# Altium schematic sheet 03_Relay.SchDoc(03_Relay)
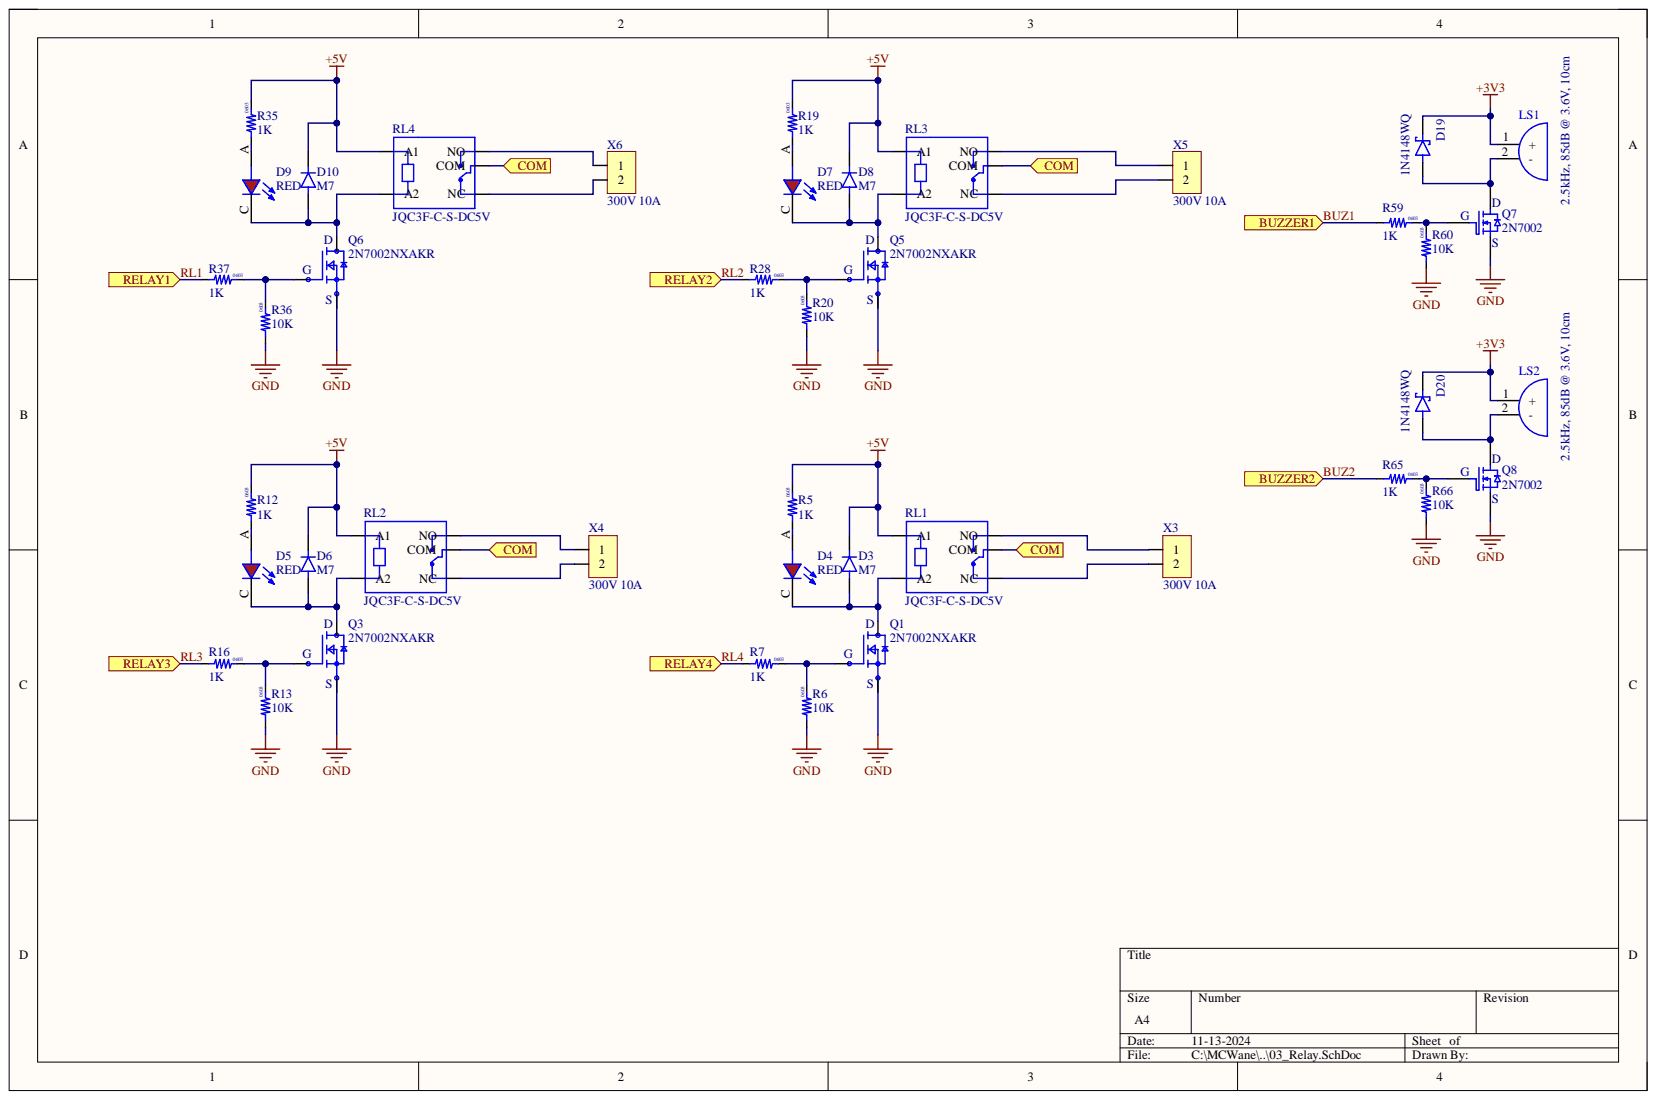
[diagram p0: 03_Relay.SchDoc]

## Parts
D3  M7  [Diode_SMA_DO-214AC]
D4  RED  [LED_0603]
D5  RED  [LED_0603]
D6  M7  [Diode_SMA_DO-214AC]
D7  RED  [LED_0603]
D8  M7  [Diode_SMA_DO-214AC]
D9  RED  [LED_0603]
D10  M7  [Diode_SMA_DO-214AC]
D19  1N4148WQ  [SOD123]
D20  1N4148WQ  [SOD123]
LS1  2.5kHz, 85dB @ 3.6V, 10cm  [SMT-0825-T-HT-R]
LS2  2.5kHz, 85dB @ 3.6V, 10cm  [SMT-0825-T-HT-R]
Q1  2N7002NXAKR  [SOT23]
Q3  2N7002NXAKR  [SOT23]
Q5  2N7002NXAKR  [SOT23]
Q6  2N7002NXAKR  [SOT23]
Q7  2N7002  [SOT23]
Q8  2N7002  [SOT23]
R5  1K  Package: 0603  [RES_0603]
R6  10K  Package: 0603  [RES_0603]
R7  1K  Package: 0603  [RES_0603]
R12  1K  Package: 0603  [RES_0603]
R13  10K  Package: 0603  [RES_0603]
R16  1K  Package: 0603  [RES_0603]
R19  1K  Package: 0603  [RES_0603]
R20  10K  Package: 0603  [RES_0603]
R28  1K  Package: 0603  [RES_0603]
R35  1K  Package: 0603  [RES_0603]
R36  10K  Package: 0603  [RES_0603]
R37  1K  Package: 0603  [RES_0603]
R59  1K  Package: 0603  [RES_0603]
R60  10K  Package: 0603  [RES_0603]
R65  1K  Package: 0603  [RES_0603]
R66  10K  Package: 0603  [RES_0603]
RL1  JQC3F-C-S-DC5V  [RELAY_SUGAR_CUBE]
RL2  JQC3F-C-S-DC5V  [RELAY_SUGAR_CUBE]
RL3  JQC3F-C-S-DC5V  [RELAY_SUGAR_CUBE]
RL4  JQC3F-C-S-DC5V  [RELAY_SUGAR_CUBE]
X3  300V 10A  [Terminal_2_Pin_5.08mm_Screw]
X4  300V 10A  [Terminal_2_Pin_5.08mm_Screw]
X5  300V 10A  [Terminal_2_Pin_5.08mm_Screw]
X6  300V 10A  [Terminal_2_Pin_5.08mm_Screw]

## Ports
BUZZER1, BUZZER2, COM, RELAY1, RELAY2, RELAY3, RELAY4

## Nets
+3V3: D19.C D20.C LS1.1 LS2.1
+5V: D3.C D6.C D8.C D10.C R5.2 R12.2 R19.2 R35.2 RL1.A1 RL2.A1 RL3.A1 RL4.A1
GND: Q1.2 Q3.2 Q5.2 Q6.2 Q7.2 Q8.2 R6.1 R13.1 R20.1 R36.1 R60.1 R66.1
BUZ1: R59.1 ; port BUZZER1
BUZ2: R65.1 ; port BUZZER2
COM: RL1.COM RL2.COM RL3.COM RL4.COM ; port COM
RL1: R37.1 ; port RELAY1
RL2: R28.1 ; port RELAY2
RL3: R16.1 ; port RELAY3
RL4: R7.1 ; port RELAY4
NetD3_A: D3.A D4.C Q1.3 RL1.A2
NetD4_A: D4.A R5.1
NetD5_A: D5.A R12.1
NetD5_C: D5.C D6.A Q3.3 RL2.A2
NetD7_A: D7.A R19.1
NetD7_C: D7.C D8.A Q5.3 RL3.A2
NetD9_A: D9.A R35.1
NetD9_C: D9.C D10.A Q6.3 RL4.A2
NetD19_A: D19.A LS1.2 Q7.3
NetD20_A: D20.A LS2.2 Q8.3
NetQ1_1: Q1.1 R6.2 R7.2
NetQ3_1: Q3.1 R13.2 R16.2
NetQ5_1: Q5.1 R20.2 R28.2
NetQ6_1: Q6.1 R36.2 R37.2
NetQ7_1: Q7.1 R59.2 R60.2
NetQ8_1: Q8.1 R65.2 R66.2
NetRL1_NC: RL1.NC X3.2
NetRL1_NO: RL1.NO X3.1
NetRL2_NC: RL2.NC X4.2
NetRL2_NO: RL2.NO X4.1
NetRL3_NC: RL3.NC X5.2
NetRL3_NO: RL3.NO X5.1
NetRL4_NC: RL4.NC X6.2
NetRL4_NO: RL4.NO X6.1

## Library data of the parts
D3 D6 D8 D10 = M7: SMD package of popular diode 1N4007 (1A at 1000V), SMD Package; Brand: Kingtronics; Component Kind: Standard; Library Name: Active_Components.SchLib; Manufacturer Part Number: M7; Marking: M7; Package: SMA DO214AC
D4 D5 D7 D9 = RED_LED_0603: 5mA 28.5mcd 2.2V 632nm Colorless transparence -40℃~+85℃ 617.5nm~633.5nm Red 120° 60mW 0603(LED); Manufacturer Part Number: 19-217/R6C-AL1M2VY/3T; Brand: Everlight Elec; Component Kind: Standard; Library Name: Active_Components.SchLib; Package: 0603
D19 D20 = 1N4148WQ: Diode 100 V 300mA Surface Mount SOD-123; Manufacturer Part Number: 1N4148WQ-13-F; Brand: DIODES INC; Component Kind: Standard; LCSC Part: C3151708; Library Name: Active_Components.SchLib; Package: SOD-123
LS1 LS2 = SMT-0825-T-HT-R: Buzzers Transducer, Externally Driven Electromechanical/Magnetic 100mA 2.5kHz 85dB @ 3.6V, 10cm Surface Mount Solder Pads; Manufacturer: PUI Audio, Inc.; Voltage rating: 2 ~ 5V; Component Kind: Standard; Datasheet Web: https://www.puiaudio.com/media/SpecSheet/SMT-0825-T-HT-R.pdf; Operating Temperature: -40°C ~ 105°C; Package: Surface Mount; PartNumber: SMT-0825-T-HT-R; Type: Buzzer, Externally driven; Value: 2.5kHz, 85dB @ 3.6V, 10cm; Library Name: Amerex Electronics.SchLib
Q1 Q3 Q5-Q8 = 2N7002NXAKR: Power MOSFET, Trench, N Channel, 60 V, 190 mA, 3 ohm, TO-236AB, Surface Mount; Brand: Nexperia; Component Kind: Standard; Library Name: Active_Components.SchLib; Manufacturer Part Number: 2N7002NXAKR; Package: SOT-23-3
R5 R7 R12 R16 R19 R28 R35 R37 R59 R65 = R0603-1K-1%: SMD Chip Resistor, 1 kohm, ± 1%, 100 mW, 0603 [1608 Metric], Thick Film, General Purpose; Manufacturer Part Number: RC0603FR-071KL; Brand: YAGEO; Marking: 1001; Component: Resistor; Component Kind: Standard; LCSC Part: C109318; Library Name: Passive_Components.SchLib; Supplier: ELEMENT 14
R6 R13 R20 R36 R60 R66 = R0603-10K-1%: SMD Chip Resistor, 10 kohm, ± 1%, 100 mW, 0603 [1608 Metric], Thick Film, General Purpose; Manufacturer Part Number: RC0603FR-0710KL; Brand: YAGEO; Marking: 1002; Component: Resistor; Component Kind: Standard; LCSC Part: C98220; Library Name: Passive_Components.SchLib; Supplier: ELEMENT 14
RL1-RL4 = Relay_CUBE_5V: 5V 7A 5Pin SPDT Subminiature High Power Relay PCB Mount; Brand: HE Relays; Component Kind: Standard; Library Name: CONNECTOR.SchLib; Manufacturer Part Number: JQC3F-C-S-DC5V; Package: 19.5X15.5X15.5mm
X3-X6 = Terminal_2_pin_5.08mm: Wire-To-Board Terminal Block, 5.08 mm, 2 Ways, 26 AWG, 16 AWG, 1.5 mm², Screw; Manufacturer Part Number: 1729128; Brand: PHOENIX CONTACT; Component Kind: Standard; Library Name: CONNECTOR.SchLib; Package: TH_5.08mm
